# Altium schematic sheet COMM ARM.SchDoc("COMM ARM")
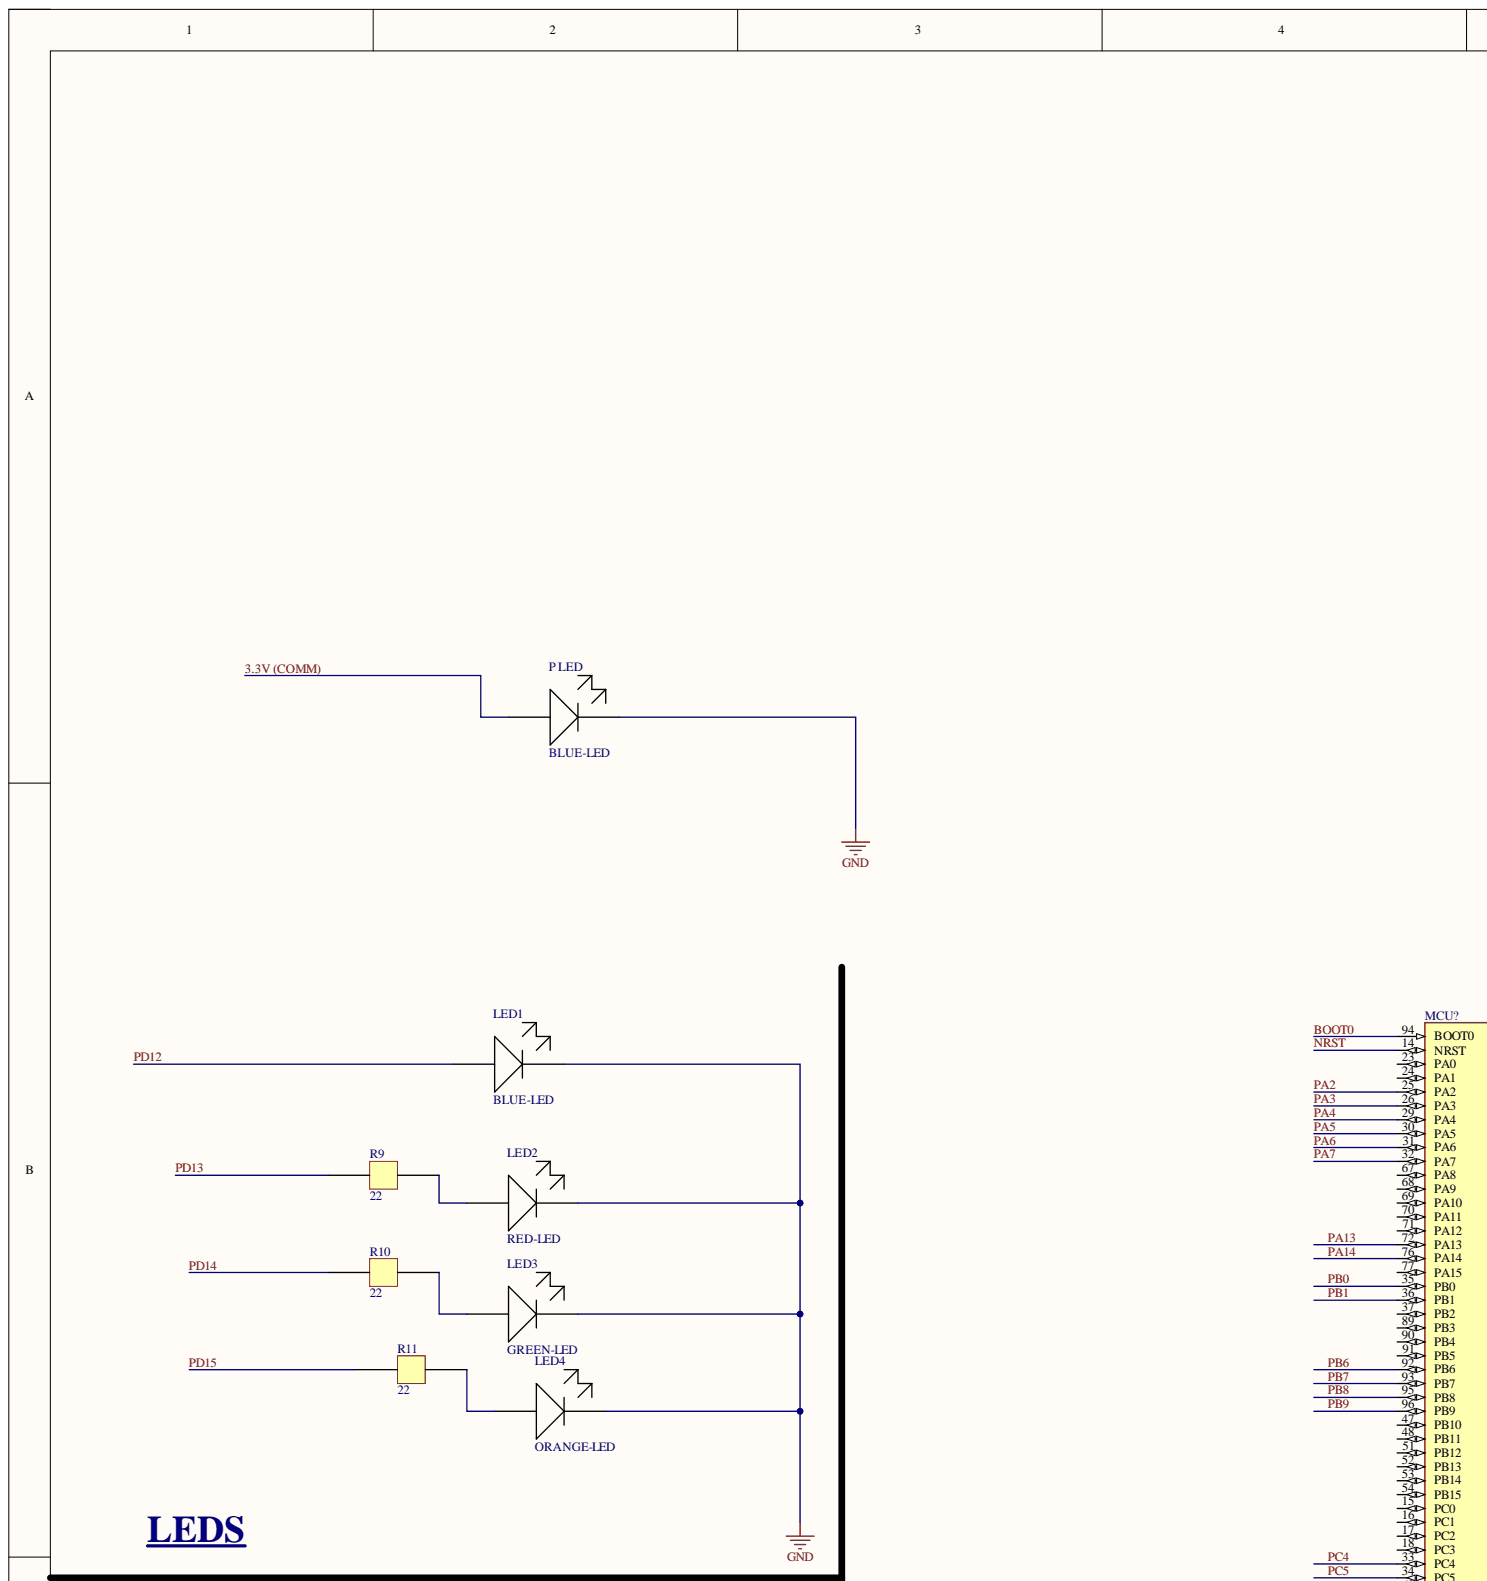
[diagram p0: COMM ARM.SchDoc, top left]
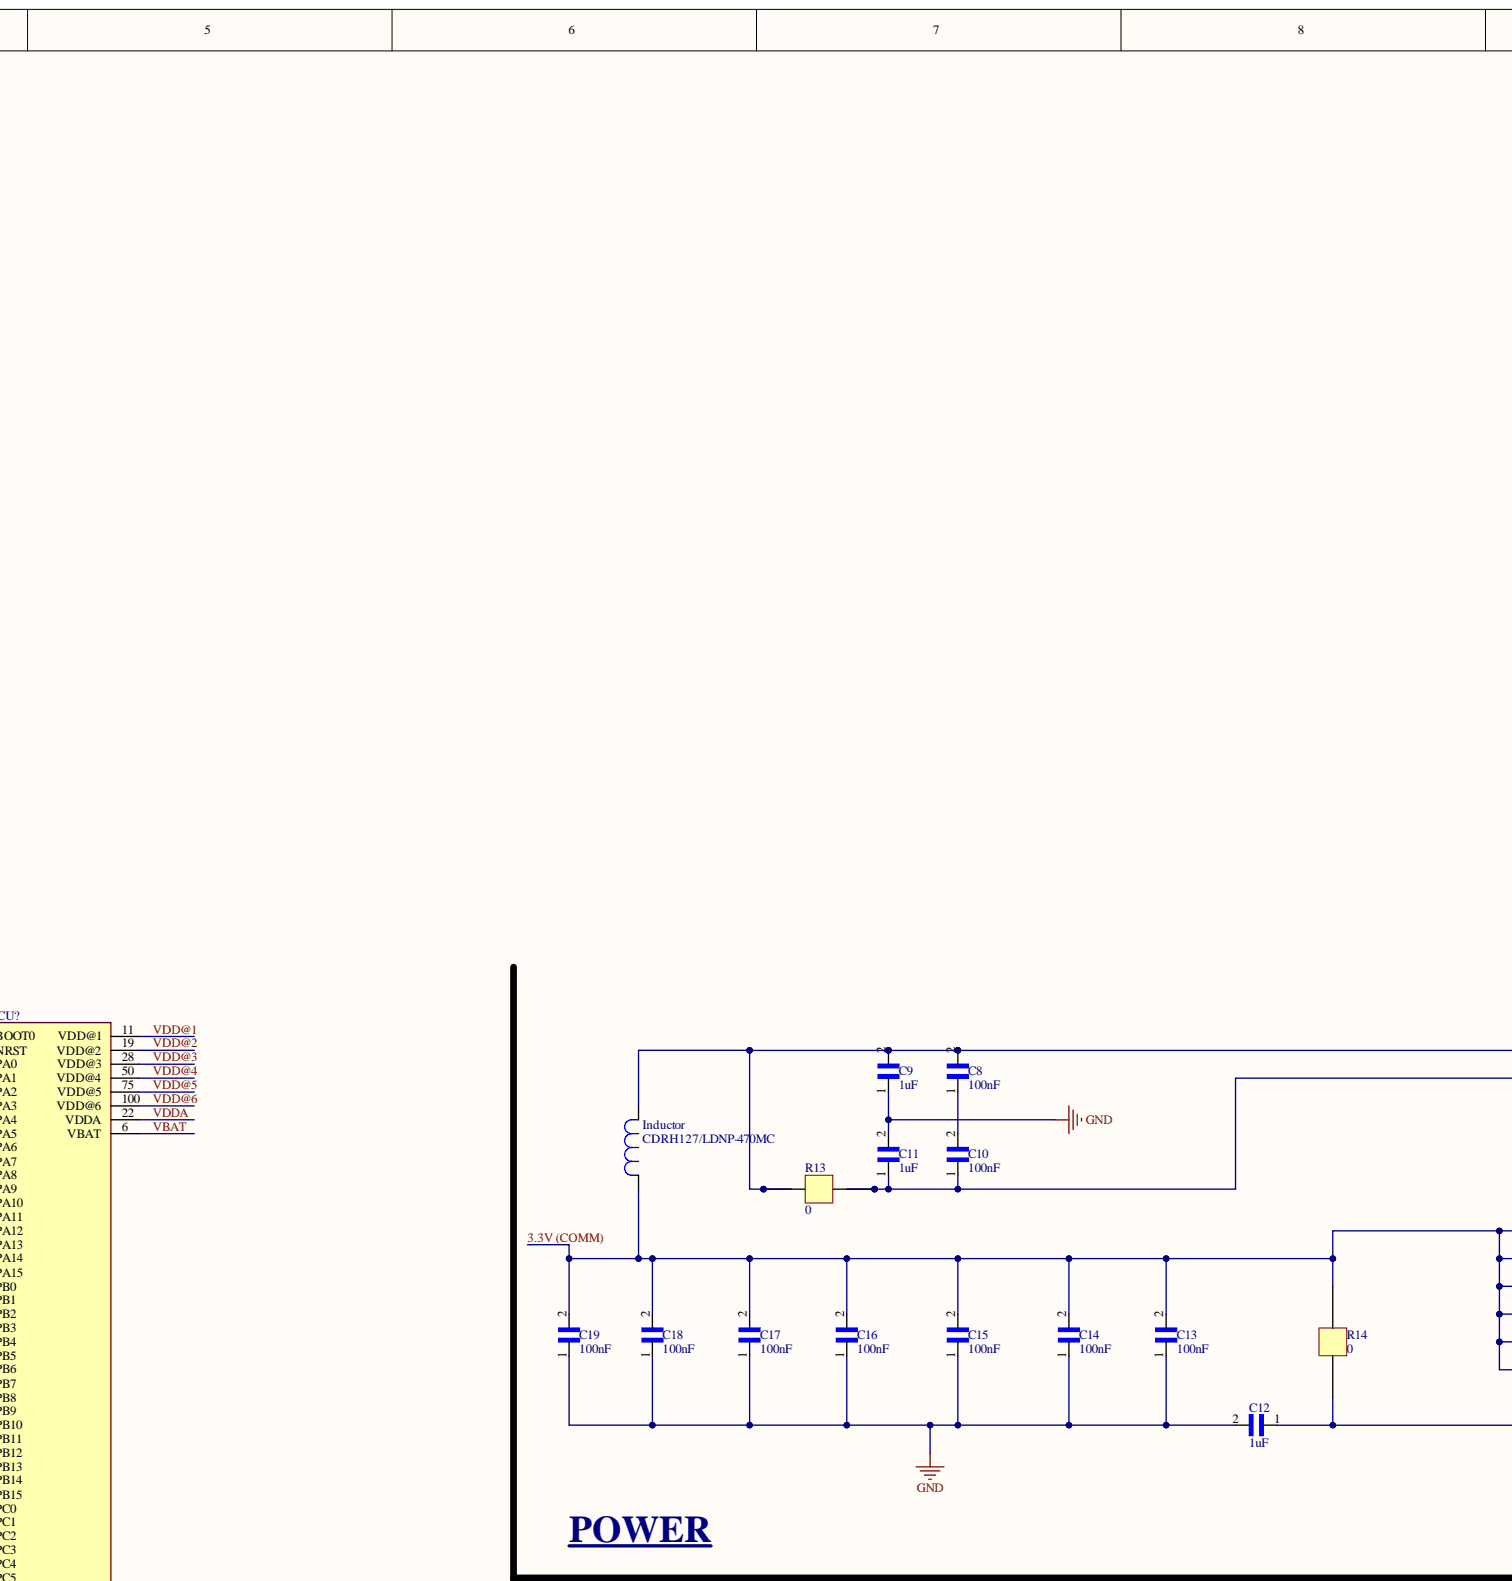
[diagram p1: COMM ARM.SchDoc, top centre]
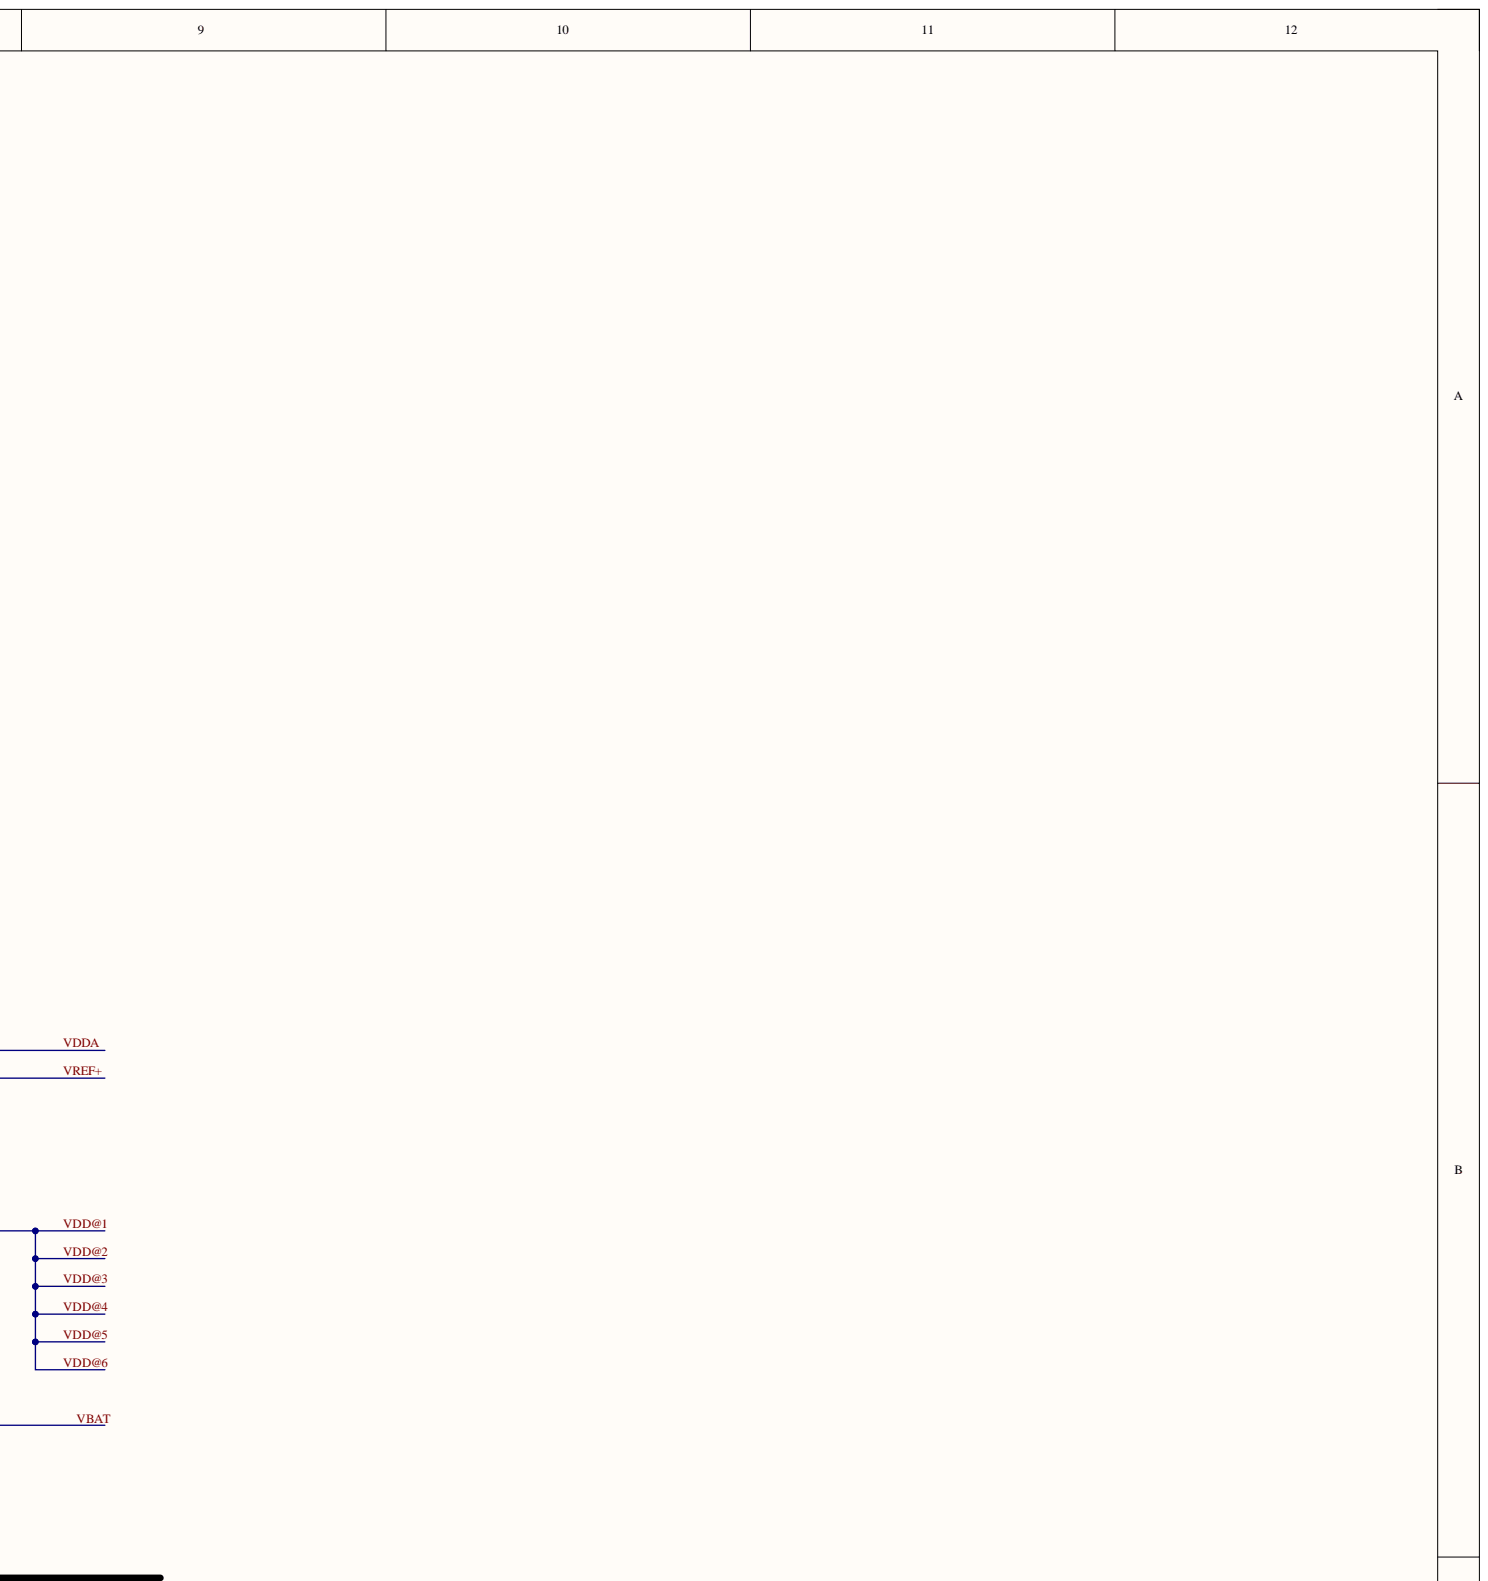
[diagram p2: COMM ARM.SchDoc, top right]
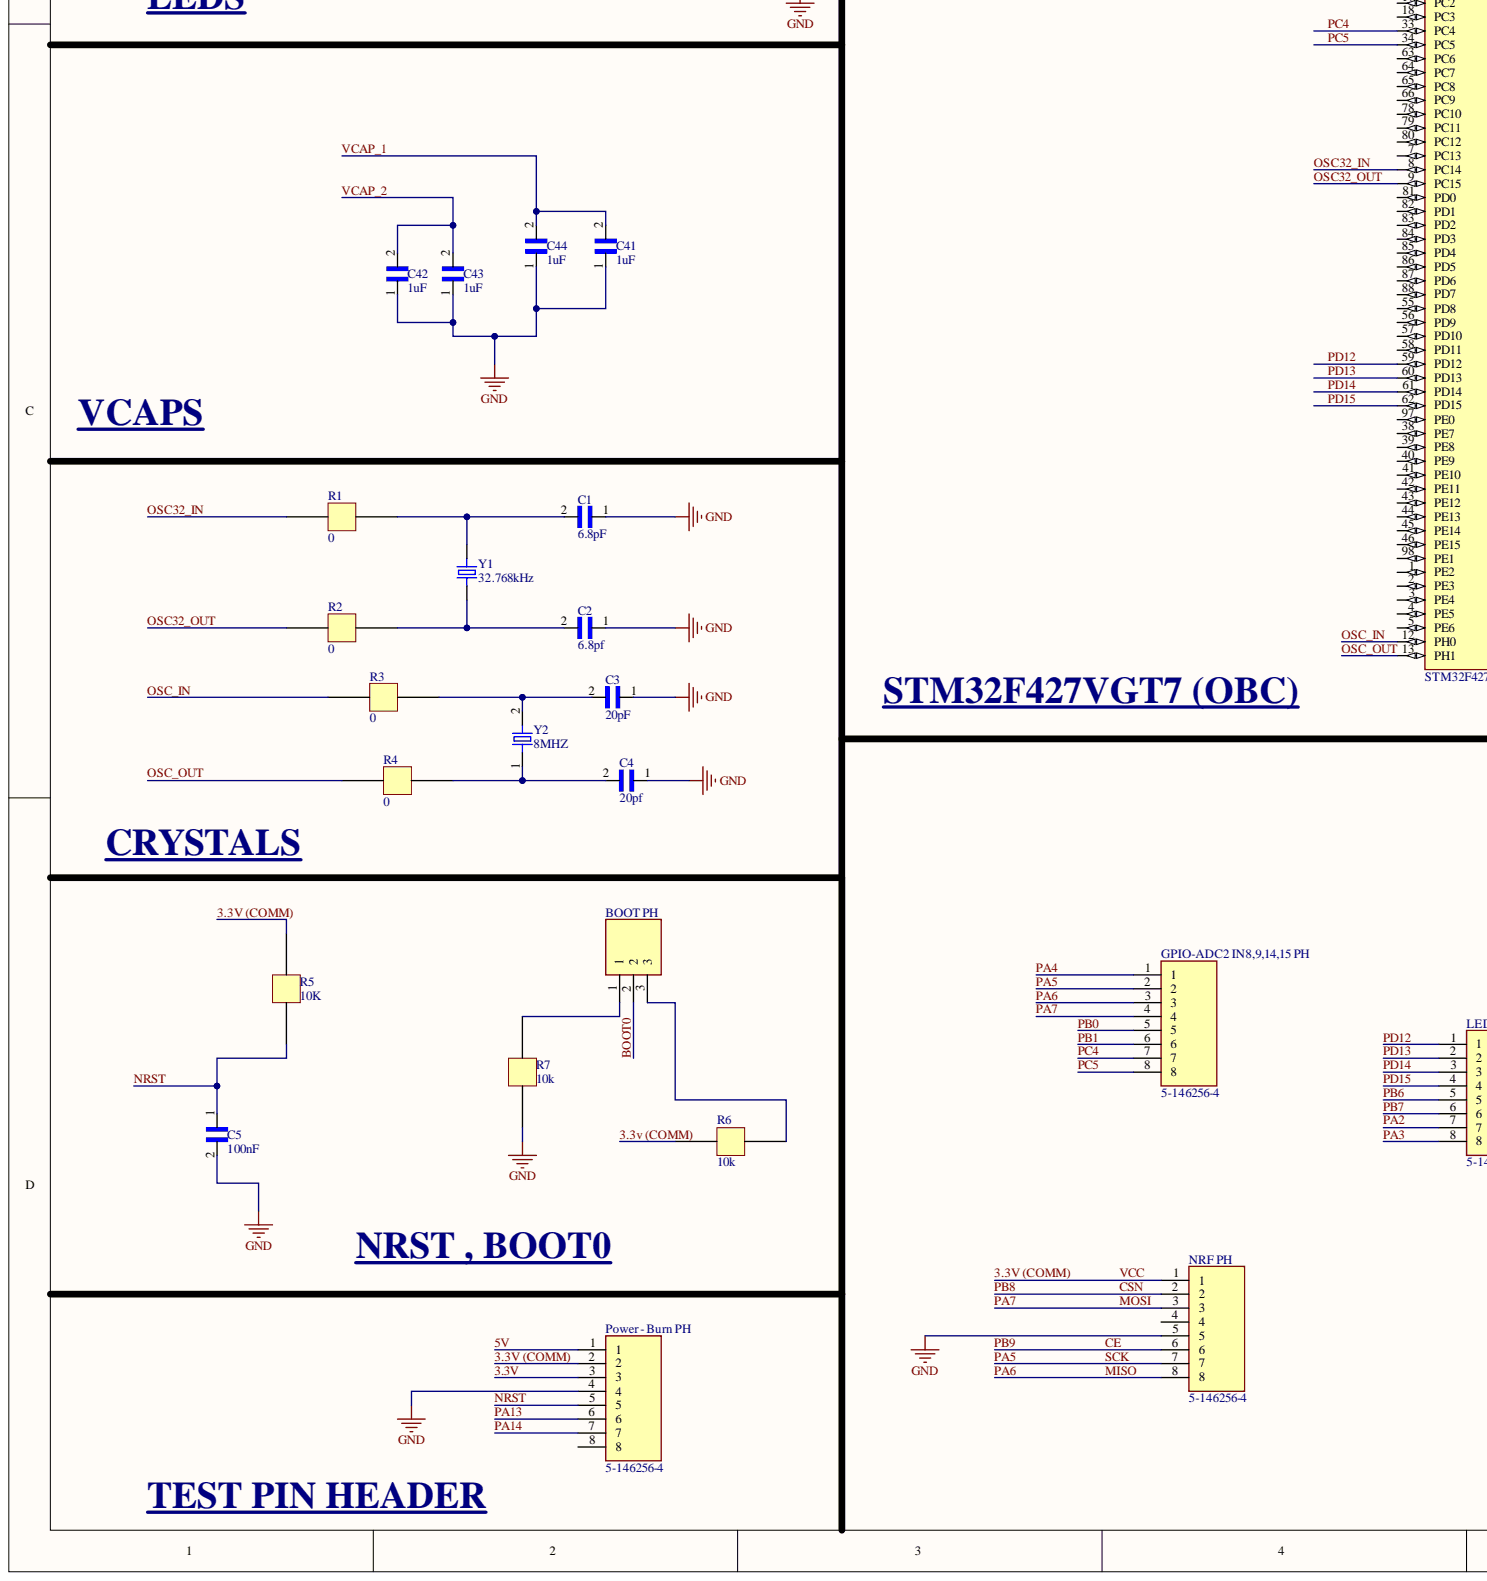
[diagram p3: COMM ARM.SchDoc, bottom left]
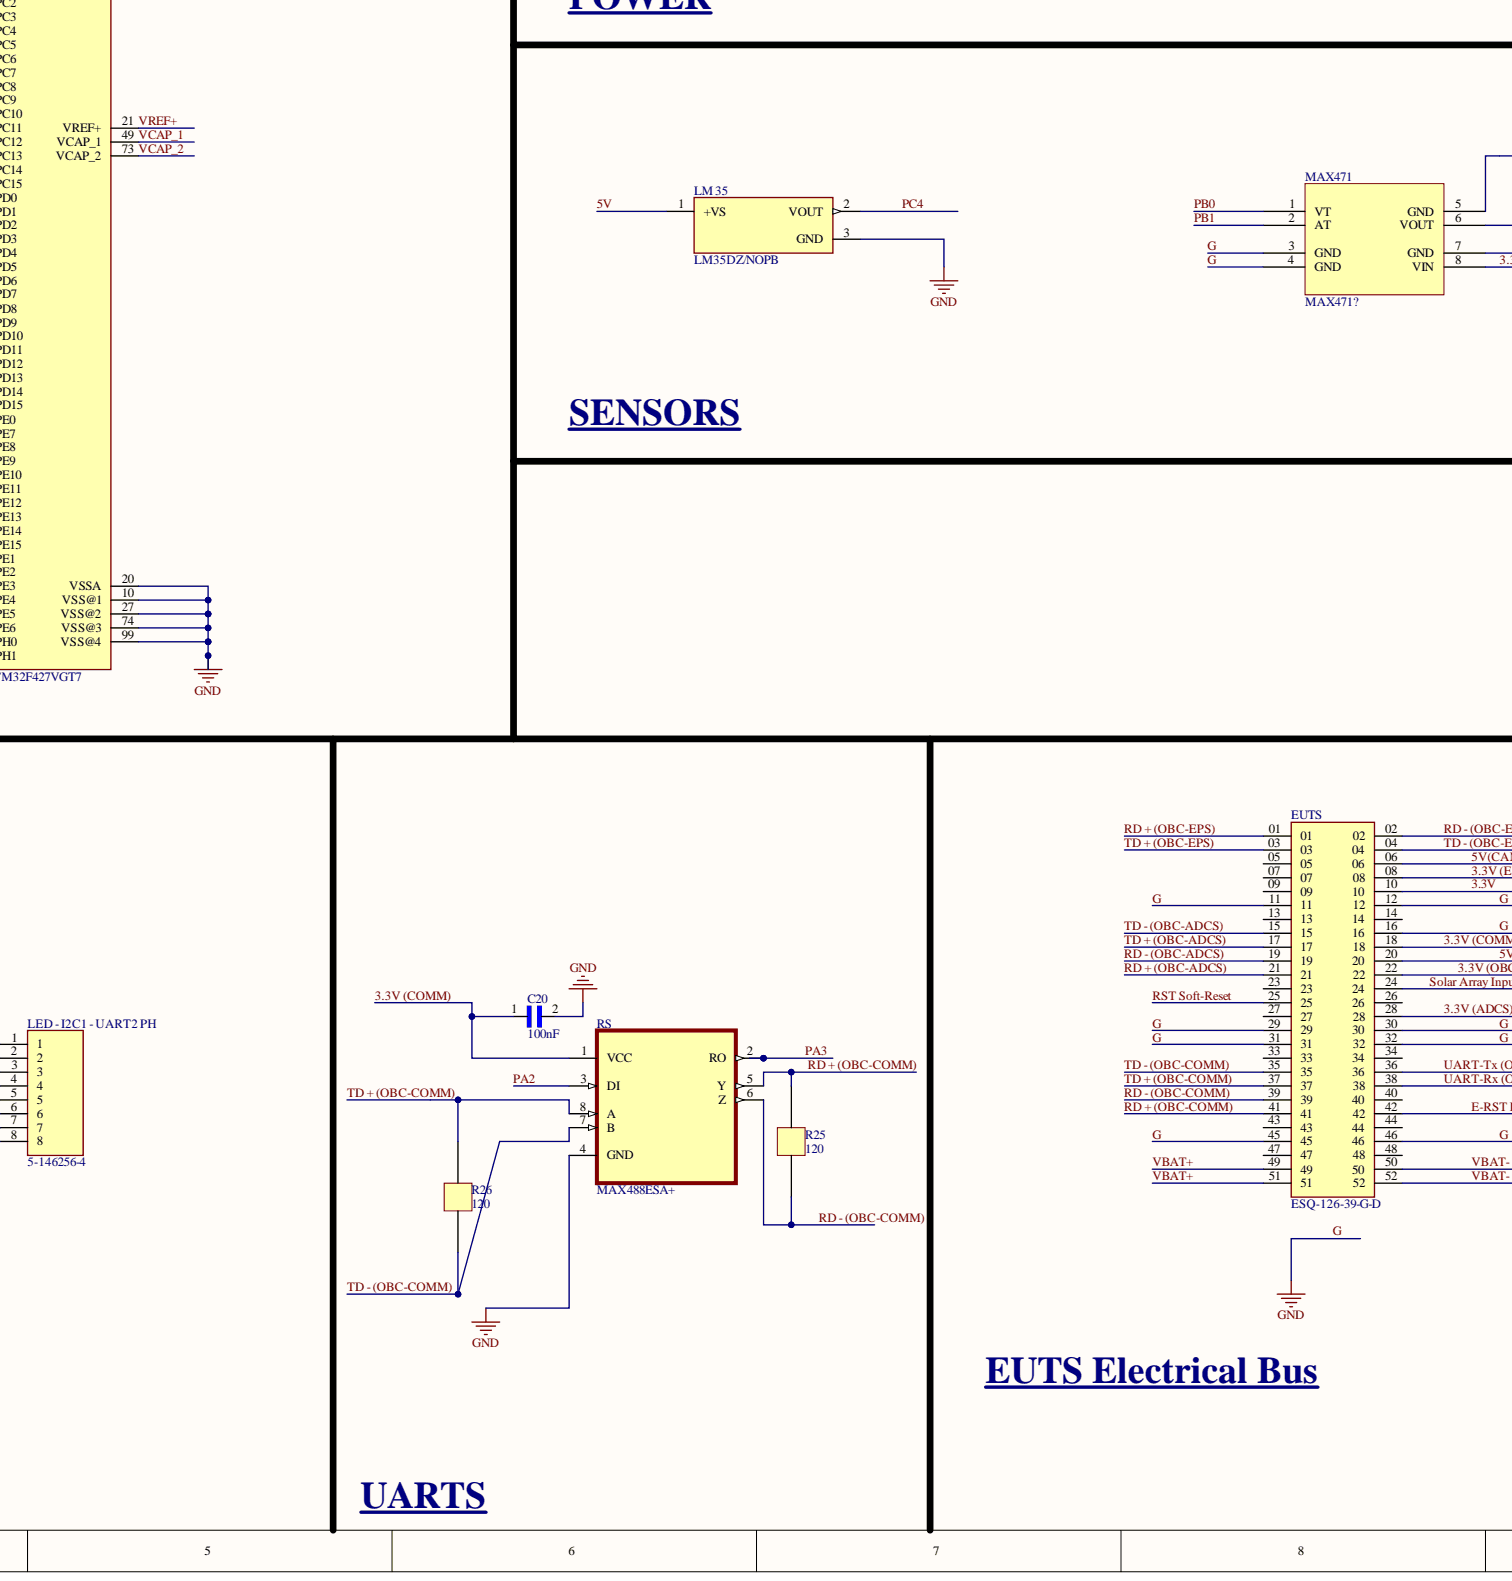
[diagram p4: COMM ARM.SchDoc, bottom centre]
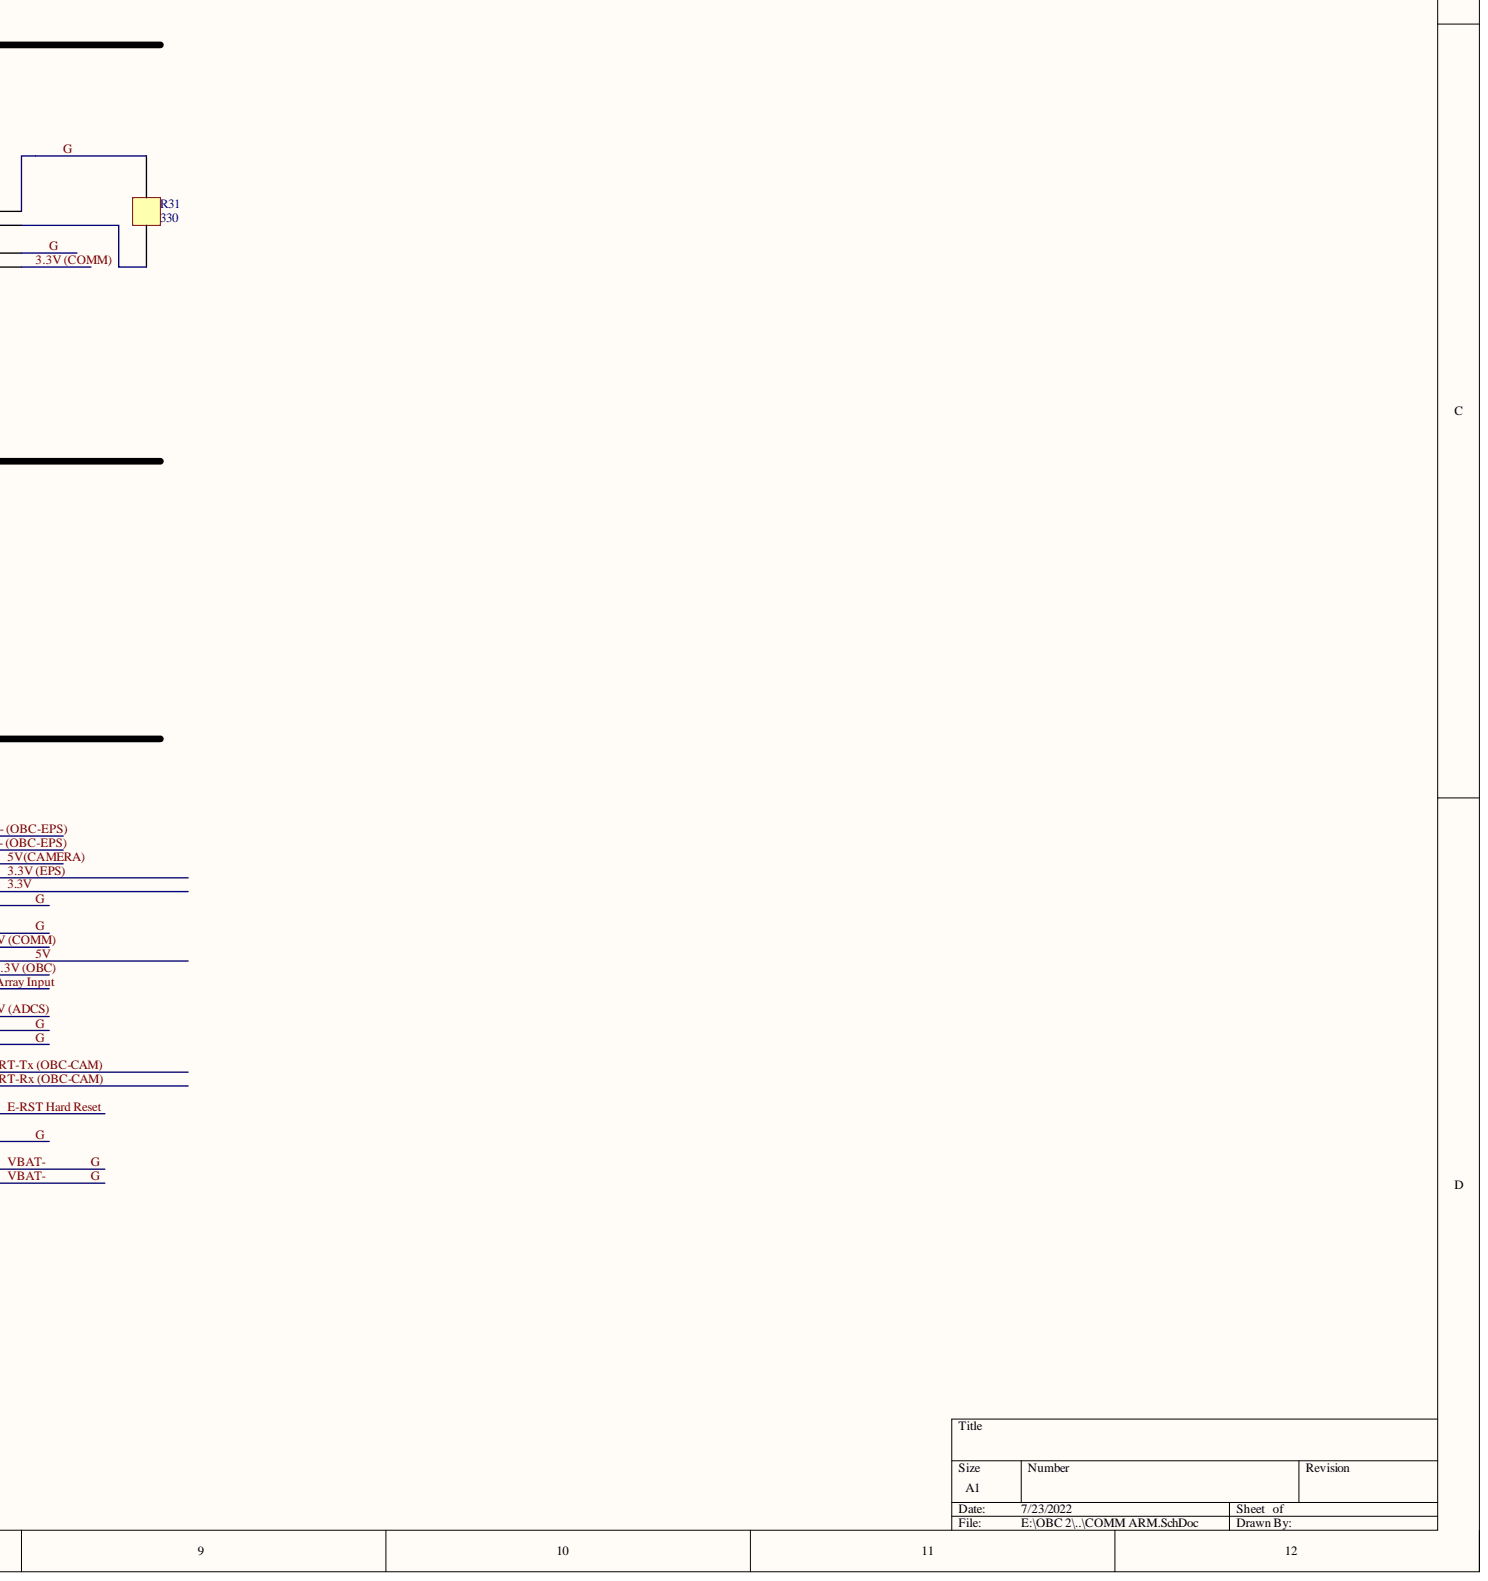
[diagram p5: COMM ARM.SchDoc, bottom right]

## Parts
BOOT_PH  4-103327-5  [TE_4-103327-5]  (value not drawn)
C1  6.8pF  [CAPC2012X95N]
C2  6.8pf  [CAPC2012X95N]
C3  20pF  [CAPC2012X95N]
C4  20pf  [CAPC2012X95N]
C5  100nF  [CAPC2012X95N]
C8  100nF  [CAPC2012X95N]
C9  1uF  [CAPC2012X95N]
C10  100nF  [CAPC2012X95N]
C11  1uF  [CAPC2012X95N]
C12  1uF  [CAPC2012X95N]
C13  100nF  [CAPC2012X95N]
C14  100nF  [CAPC2012X95N]
C15  100nF  [CAPC2012X95N]
C16  100nF  [CAPC2012X95N]
C17  100nF  [CAPC2012X95N]
C18  100nF  [CAPC2012X95N]
C19  100nF  [CAPC2012X95N]
C20  100nF  [CAPC2012X95N]
C41  1uF  [CAPC2012X95N]
C42  1uF  [CAPC2012X95N]
C43  1uF  [CAPC2012X95N]
C44  1uF  [CAPC2012X95N]
EUTS  ESQ-126-39-G-D  [SAMTEC_ESQ-126-39-G-D]
GPIO-ADC2_IN8,9,14,15_PH  5-146256-4  [TE_5-146256-4]
Inductor  CDRH127/LDNP-470MC  [IND_CDRH127/LDNP-470MC_SUM]
LED1  BLUE-LED  [RESC3015X65]
LED2  RED-LED  [RESC3015X65]
LED3  GREEN-LED  [RESC3015X65]
LED4  ORANGE-LED  [RESC3015X65]
LED_-_I2C1_-_UART2_PH  5-146256-4  [TE_5-146256-4]
LM_35  LM35DZ/NOPB  [TO92127P521H735-3]
MAX471  MAX471?  [PCBComponent_1]
MCU?  STM32F427VGT7  [QFP50P1600X1600X160-100N]
NRF_PH  5-146256-4  [TE_5-146256-4]
P_LED  BLUE-LED  [RESC3015X65]
Power_-_Burn_PH  5-146256-4  [TE_5-146256-4]
R1  0  [CAPC2012X95N]
R2  0  [CAPC2012X95N]
R3  0  [CAPC2012X95N]
R4  0  [CAPC2012X95N]
R5  10K  [CAPC2012X95N]
R6  10k  [CAPC2012X95N]
R7  10k  [CAPC2012X95N]
R9  22  [CAPC2012X95N]
R10  22  [CAPC2012X95N]
R11  22  [CAPC2012X95N]
R13  0  [CAPC2012X95N]
R14  0  [CAPC2012X95N]
R25  120  [CAPC2012X95N]
R26  120  [CAPC2012X95N]
R31  330  [CAPC2012X95N]
RS  MAX488ESA+  [SOIC127P600X175-8N]
Y1  32.768kHz  [XTAL_ECS-.327-12.5-34S-TR]
Y2  8MHZ  [XTAL500X320X130N]

## Nets
3.3V: EUTS.10 Power_-_Burn_PH.3
3.3V (ADCS): EUTS.28
3.3v (COMM): C13.2 C14.2 C15.2 C16.2 C17.2 C18.2 C19.2 C20.1 EUTS.18 Inductor.2 MAX471.8(VIN) MCU?.11(VDD@1) MCU?.19(VDD@2) MCU?.28(VDD@3) MCU?.50(VDD@4) MCU?.75(VDD@5) MCU?.100(VDD@6) NRF_PH.1 P_LED.1 Power_-_Burn_PH.2 R5.1 R6.2 R14.1 RS.1(VCC)
3.3V (EPS): EUTS.08
3.3V (OBC): EUTS.22
5V: EUTS.20 LM_35.1 Power_-_Burn_PH.1
5V(CAMERA): EUTS.06
BOOT0: BOOT_PH.2 MCU?.94(BOOT0)
CE: MCU?.96(PB9) NRF_PH.6
CSN: MCU?.95(PB8) NRF_PH.2
E-RST Hard Reset: EUTS.42
G: C1.1 C2.1 C3.1 C4.1 C5.2 C9.1 C11.2 C12.2 C13.1 C14.1 C15.1 C16.1 C17.1 C18.1 C19.1 C20.2 C41.1 C42.1 C43.1 C44.1 EUTS.11 EUTS.12 EUTS.16 EUTS.29 EUTS.30 EUTS.31 EUTS.32 EUTS.45 EUTS.46 EUTS.50 EUTS.52 LED1.2 LED2.2 LED3.2 LED4.2 LM_35.3 MAX471.3(GND) MAX471.4(GND) MAX471.5(GND) MAX471.7(GND) MCU?.10(VSS@1) MCU?.20(VSSA) MCU?.27(VSS@2) MCU?.74(VSS@3) MCU?.99(VSS@4) NRF_PH.5 P_LED.2 Power_-_Burn_PH.4 R7.2 R31.1 RS.4(GND)
MISO: GPIO-ADC2_IN8,9,14,15_PH.3 MCU?.31(PA6) NRF_PH.8
MOSI: GPIO-ADC2_IN8,9,14,15_PH.4 MCU?.32(PA7) NRF_PH.3
NRST: C5.1 MCU?.14(NRST) Power_-_Burn_PH.5 R5.2
OSC32_IN: MCU?.8(PC14) R1.2
OSC32_OUT: MCU?.9(PC15) R2.2
OSC_IN: MCU?.12(PH0) R3.2
OSC_OUT: MCU?.13(PH1) R4.2
PA2: LED_-_I2C1_-_UART2_PH.7 MCU?.25(PA2) RS.3(DI)
PA3: LED_-_I2C1_-_UART2_PH.8 MCU?.26(PA3) RS.2(RO)
PA4: GPIO-ADC2_IN8,9,14,15_PH.1 MCU?.29(PA4)
PA5: GPIO-ADC2_IN8,9,14,15_PH.2 MCU?.30(PA5) NRF_PH.7
PA13: MCU?.72(PA13) Power_-_Burn_PH.6
PA14: MCU?.76(PA14) Power_-_Burn_PH.7
PB0: GPIO-ADC2_IN8,9,14,15_PH.5 MAX471.1(VT) MCU?.35(PB0)
PB1: GPIO-ADC2_IN8,9,14,15_PH.6 MAX471.2(AT) MCU?.36(PB1)
PB6: LED_-_I2C1_-_UART2_PH.5 MCU?.92(PB6)
PB7: LED_-_I2C1_-_UART2_PH.6 MCU?.93(PB7)
PC4: GPIO-ADC2_IN8,9,14,15_PH.7 LM_35.2 MCU?.33(PC4)
PC5: GPIO-ADC2_IN8,9,14,15_PH.8 MCU?.34(PC5)
PD12: LED1.1 LED_-_I2C1_-_UART2_PH.1 MCU?.59(PD12)
PD13: LED_-_I2C1_-_UART2_PH.2 MCU?.60(PD13) R9.2
PD14: LED_-_I2C1_-_UART2_PH.3 MCU?.61(PD14) R10.2
PD15: LED_-_I2C1_-_UART2_PH.4 MCU?.62(PD15) R11.2
RD + (OBC-ADCS): EUTS.21
RD + (OBC-COMM): EUTS.41 R25.1 RS.5(Y)
RD + (OBC-EPS): EUTS.01
RD - (OBC-ADCS): EUTS.19
RD - (OBC-COMM): EUTS.39 R25.2 RS.6(Z)
RD - (OBC-EPS): EUTS.02
RST Soft-Reset: EUTS.25
Solar Array Input: EUTS.24
TD + (OBC-ADCS): EUTS.17
TD + (OBC-COMM): EUTS.37 R26.1 RS.8(A)
TD + (OBC-EPS): EUTS.03
TD - (OBC-ADCS): EUTS.15
TD - (OBC-COMM): EUTS.35 R26.2 RS.7(B)
TD - (OBC-EPS): EUTS.04
UART-Rx (OBC-CAM): EUTS.38
UART-Tx (OBC-CAM): EUTS.36
VBAT: C12.1 MCU?.6(VBAT) R14.2
VBAT+: EUTS.49 EUTS.51
VCAP_1: C41.2 C44.2 MCU?.49(VCAP_1)
VCAP_2: C42.2 C43.2 MCU?.73(VCAP_2)
VDDA: C8.2 C9.2 Inductor.1 MCU?.22(VDDA) R13.2
VREF+: C10.1 C11.1 MCU?.21(VREF+) R13.1
NetBOOT PH_1: BOOT_PH.1 R7.1
NetBOOT PH_3: BOOT_PH.3 R6.1
NetC1_2: C1.2 R1.1 Y1.2
NetC2_2: C2.2 R2.1 Y1.1
NetC3_2: C3.2 R3.1 Y2.2
NetC4_2: C4.2 R4.1 Y2.1
NetC8_1: C8.1 C10.2
NetLED2_1: LED2.1 R9.1
NetLED3_1: LED3.1 R10.1
NetLED4_1: LED4.1 R11.1
NetMAX471_6: MAX471.6(VOUT) R31.2

Not connected: EUTS 05 07 09 13 14 23 26 27 33 34 40 43 44 47 48; MCU? 1(PE2) 2(PE3) 3(PE4) 4(PE5) 5(PE6) 7(PC13) 15(PC0) 16(PC1) 17(PC2) 18(PC3) 23(PA0) 24(PA1) 37(PB2) 38(PE7) 39(PE8) 40(PE9) 41(PE10) 42(PE11) 43(PE12) 44(PE13) 45(PE14) 46(PE15) 47(PB10) 48(PB11) 51(PB12) 52(PB13) 53(PB14) 54(PB15) 55(PD8) 56(PD9) 57(PD10) 58(PD11) 63(PC6) 64(PC7) 65(PC8) 66(PC9) 67(PA8) 68(PA9) 69(PA10) 70(PA11) 71(PA12) 77(PA15) 78(PC10) 79(PC11) 80(PC12) 81(PD0) 82(PD1) 83(PD2) 84(PD3) 85(PD4) 86(PD5) 87(PD6) 88(PD7) 89(PB3) 90(PB4) 91(PB5) 97(PE0) 98(PE1); NRF_PH 4; Power_-_Burn_PH 8

## Library data of the parts
BOOT_PH = 4-103327-5: Conn Unshrouded Header HDR 3 POS 2.54mm Solder ST Thru-Hole Carton; MF: TE Connectivity; Availability: Unavailable; Component Kind: Standard; EU_RoHS_Compliance: Compliant; Library Name: 4-103327-5.IntLib; MP: 4-103327-5; Package: None; Price: None; SnapEDA_Link: https://www.snapeda.com/parts/4-103327-5/TE%20Connectivity%20AMP%20Connectors/view-part/2403997/?ref=snap; TE_purchase_URL: https://www.te.com/usa-en/product-4-103327-5.html?te_bu=Cor&te_type=disp&te_campaign=seda_glo_cor-seda-global-disp-prtnr-fy19-seda-model-bom-cta_sma-317_1&elqCampaignId=32493
C1-C5 C8-C20 C41-C44 = UMK212SD103KD-T: CAP CER 10000PF 50V 0805; Availability: Unavailable; Component Kind: Standard; Library Name: UMK212SD103KD-T.IntLib; MP: UMK212SD103KD-T; Package: None; Price: None
EUTS = ESQ-126-39-G-D: 52 Position Elevated Socket Connector Through Hole; MF: Samtec; Availability: Unavailable; Component Kind: Standard; Library Name: ESQ-126-39-G-D.IntLib; MP: ESQ-126-39-G-D; Package: None; Price: None; SnapEDA_Link: https://www.snapeda.com/parts/ESQ-126-39-G-D/Samtec%20Inc./view-part/490181/?ref=snap
GPIO-ADC2_IN8,9,14,15_PH LED_-_I2C1_-_UART2_PH NRF_PH Power_-_Burn_PH = 5-146256-4: 08 MODII HDR DRST B/A .100CL LF; MF: TE Connectivity; Availability: Unavailable; Component Kind: Standard; Library Name: 5-146256-4.IntLib; MP: 5-146256-4; Package: None; Price: None; SnapEDA_Link: https://www.snapeda.com/parts/5-146256-4/TE%20Connectivity%20AMP%20Connectors/view-part/500529/?ref=snap
Inductor = CDRH127/LDNP-470MC: 47ÂµH Shielded Inductor 3.25A 78mOhm Max Nonstandard; MF: Sumida America; Check_prices: https://www.snapeda.com/parts/CDRH127/LDNP-470MC/Sumida%20America%20Components%20Inc./view-part/547921/?ref=eda; Component Kind: Standard; Digi-Key_Part_Number: 308-1339-1-ND; Library Name: CDRH127_LDNP-470MC.IntLib; MP: CDRH127/LDNP-470MC; Package: Nonstandard Sumida; Purchase-URL: https://pricing.snapeda.com/search/part/CDRH127/LDNP-470MC/?ref=eda; SnapEDA_Link: https://www.snapeda.com/parts/CDRH127/LDNP-470MC/Sumida%20America%20Components%20Inc./view-part/547921/?ref=snap
LED1-LED4 P_LED = LTST-C150TBKT: LED Blue 470nm LED Indication 3.3V; Component Kind: Standard; Library Name: Satellite.SchLib
LM_35 = LM35DZ/NOPB: Temperature Sensor Analog, Local 0Â°C ~ 100Â°C 10mV/Â°C TO-92-3; MF: Texas Instruments; MP: LM35DZ; Availability: Not in stock; Check_prices: https://www.snapeda.com/parts/LM35DZ/Texas+Instruments/view-part/?ref=eda; Component Kind: Standard; Library Name: LM35DZ_NOPB.IntLib; Package: E-PKG AXIAL-LEADED-2 ON Semiconductor; Price: None; SnapEDA_Link: https://www.snapeda.com/parts/LM35DZ/Texas+Instruments/view-part/?ref=snap
MAX471 = MAX471?: MAX471 Voltage And Current Sensor Module Consume Voltage Load Detection Module; Component Kind: Standard; Library Name: MAX471.SchLib
MCU? = STM32F427VGT7: ARMÂ® CortexÂ®-M4 STM32F4 Microcontroller IC 32-Bit 180MHz 1MB _1M x 8_ FLASH 100-LQFP _14x14_; MF: STMicroelectronics; Availability: Unavailable; Component Kind: Standard; Library Name: STM32F427VGT7.IntLib; MP: STM32F427VGT7; Package: LQFP-100 STMicroelectronics; Price: None; SnapEDA_Link: https://www.snapeda.com/parts/STM32F427VGT7/STMicroelectronics/view-part/567621/?ref=snap
R1-R7 R9-R11 R13 R14 R25 R26 R31 = RESISTOR: Component Kind: Standard; Library Name: sat.SchLib
RS = MAX488ESA+: 1/1 Transceiver Full RS422, RS485 8-SOIC; MF: Maxim Integrated; MP: MAX488ESA+T; Availability: Unavailable; Component Kind: Standard; Library Name: MAX488ESA_.IntLib; Package: SOIC-8 Maxim; Price: None; SnapEDA_Link: https://www.snapeda.com/parts/MAX488ESA+T/Maxim%20Integrated/view-part/48834/?ref=snap
Y1 = ECS-.327-12.5-34S-TR: 32.768kHz Â±20ppm Crystal 12.5pF 70 kOhms 2-SMD, No Lead; MF: ECS Inc.; Availability: Unavailable; Component Kind: Standard; Library Name: ECS-.327-12.5-34S-TR.IntLib; MP: ECS-.327-12.5-34S-TR; Package: SMD-2 ECS International; Price: None; SnapEDA_Link: https://www.snapeda.com/parts/ECS-.327-12.5-34S-TR/ECS%20Inc./view-part/2454548/?ref=snap
Y2 = ABM3-8.000MHZ-D2Y-T: 8MHz Â±20ppm Crystal 18pF 140 Ohms 2-SMD, No Lead; MF: Abracon; Component Kind: Standard; Digi-Key_Part_Number: 535-10630-1-ND; Digi-Key_Purchase_URL: https://www.digikey.com/product-detail/en/abracon-llc/ABM3-8.000MHZ-D2Y-T/535-10630-1-ND/2344632?utm_source=snapeda&utm_medium=aggregator&utm_campaign=symbol; Library Name: ABM3-8.000MHZ-D2Y-T.IntLib; MP: ABM3-8.000MHZ-D2Y-T; Package: SMD-2 Abracon; SnapEDA_Link: https://www.snapeda.com/parts/ABM3-8.000MHZ-D2Y-T/Abracon%20LLC/view-part/106372/?ref=snap
